# Altium schematic sheet Button.SchDoc(Button)
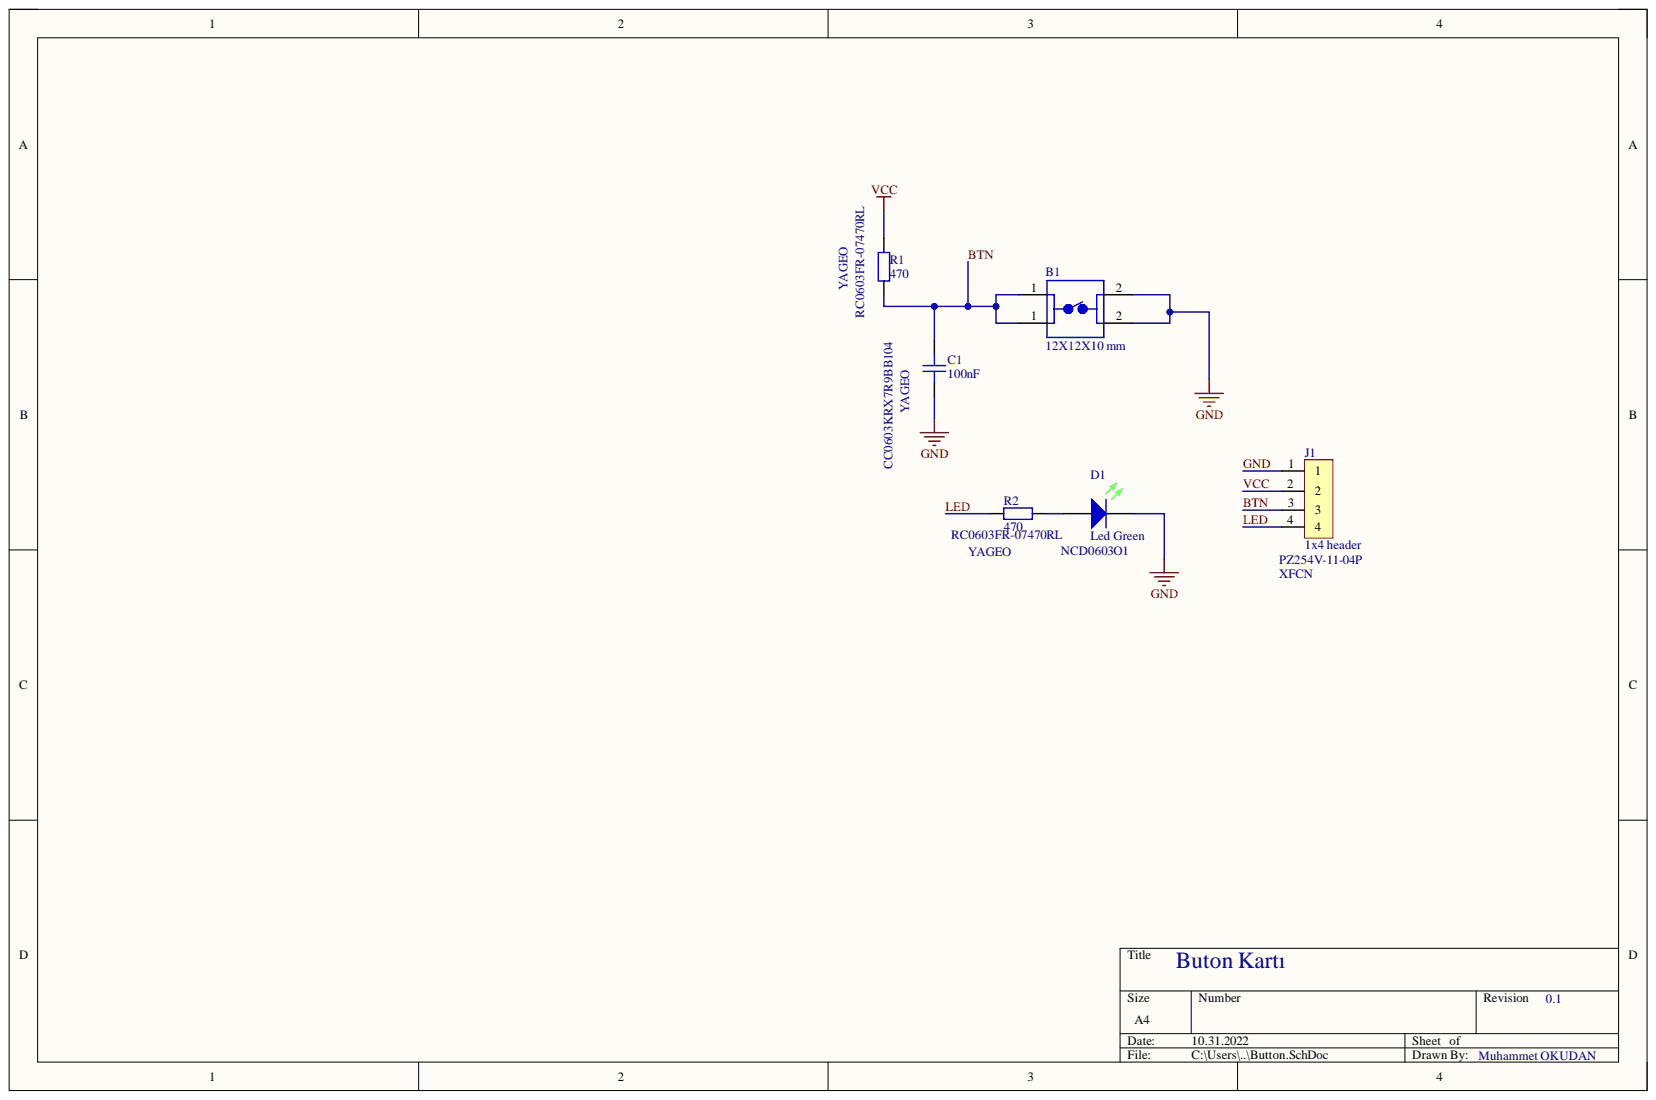
[diagram p0: Button.SchDoc]

## Parts
B1  12X12X10 mm  [12x12x10mm button]
C1  100nF  *Mfg Part#: CC0603KRX7R9BB104  Manufacturer: YAGEO  [0603 cap]
D1  Led Green  *Mfg Part#: NCD0603O1  [1206 green smd led]
J1  1x4 header  *Mfg Part#: PZ254V-11-04P  [1x4 2.54mm header]
R1  470  *Mfg Part#: RC0603FR-07470RL  [0603 res]
R2  470  [0603 res]

## Nets
BTN: B1.1 C1.2 J1.3 R1.1
GND: B1.2 C1.1 D1.2 J1.1
LED: J1.4 R2.1
VCC: J1.2 R1.2
NetD1_1: D1.1 R2.2

## Library data of the parts
B1 = buton: 12X12X10 mm 4 bacak; Component Kind: Standard; Library Name: button.SchLib
C1 = 0603 cap: Component Kind: Standard; Library Name: button.SchLib
D1 = Led Green: 1206 SMD Green Led; Manufacturer: Foshan NationStar Optoelectronics; Component Kind: Standard; Library Name: button.SchLib
J1 = 1x4 header: 1x4 2.54mm header; Manufacturer: XFCN; Component Kind: Standard; Library Name: button.SchLib
R1 = 0603 res: Manufacturer: YAGEO; Component Kind: Standard; Library Name: button.SchLib
R2 = 0603 res: *Mfg Part#: RC0603FR-07470RL; Manufacturer: YAGEO; Component Kind: Standard; Library Name: button.SchLib
